# Altium schematic sheet MCU_STM32F407_V2005.SchDoc
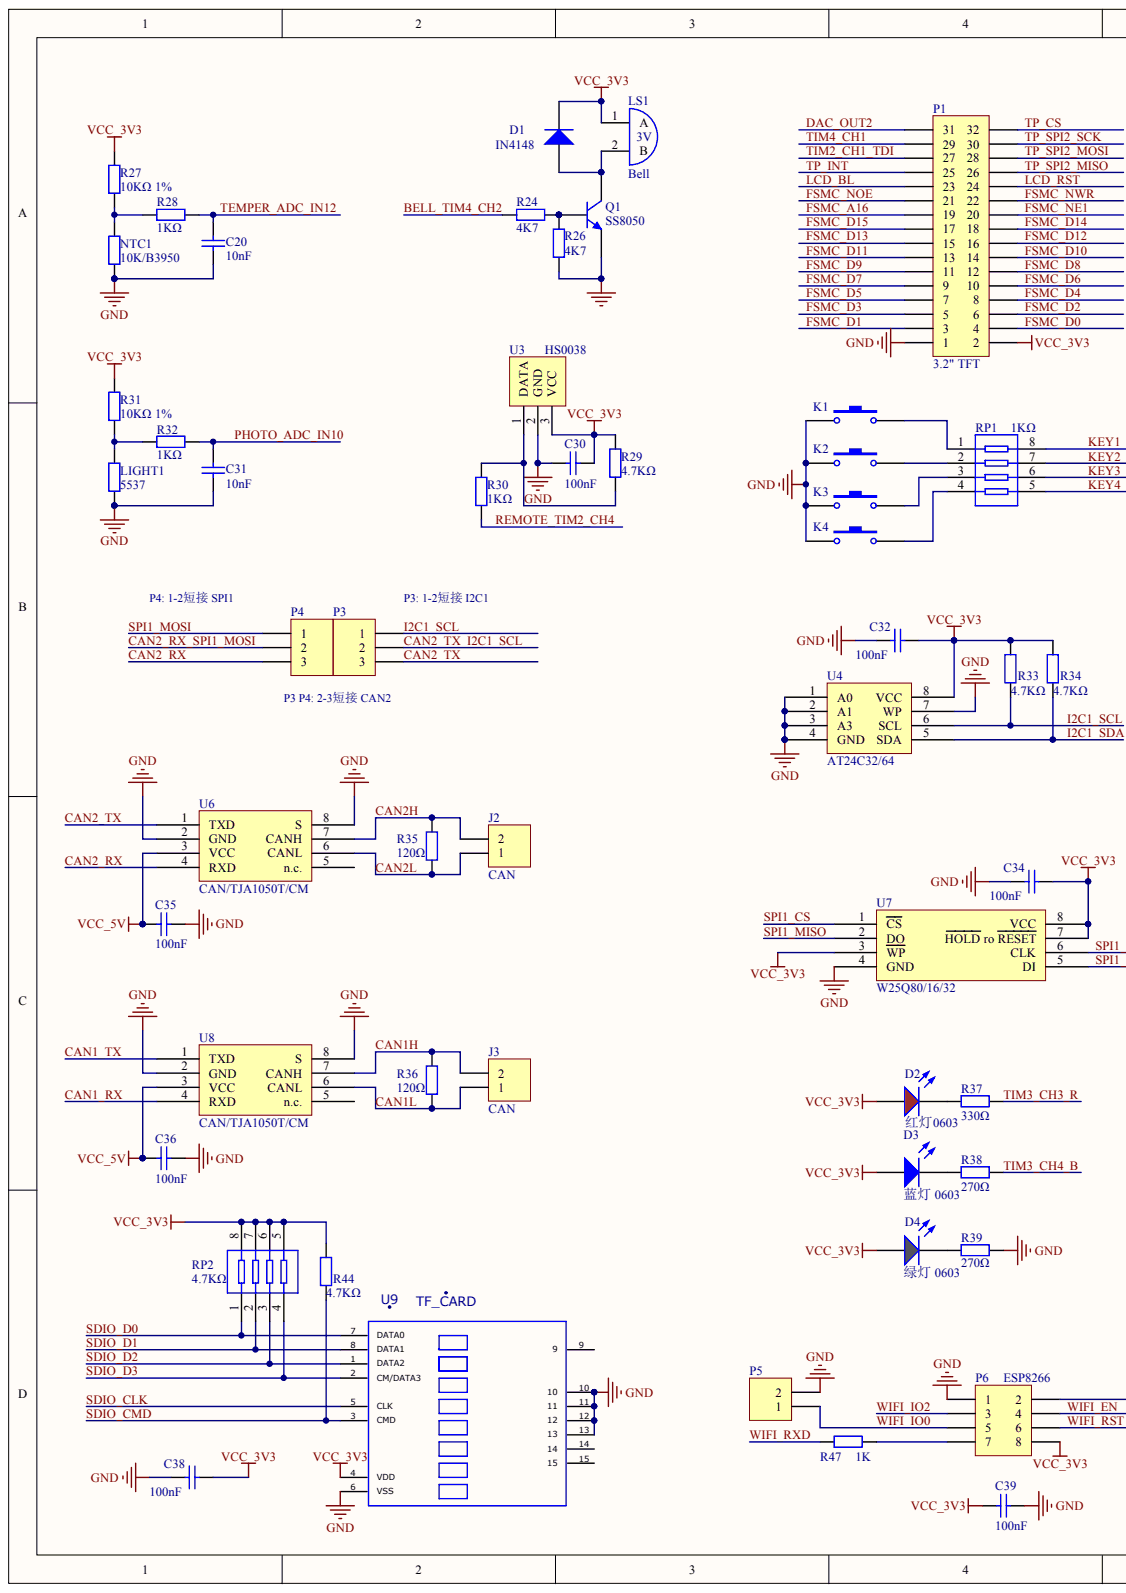
[diagram p0: MCU_STM32F407_V2005.SchDoc, left]
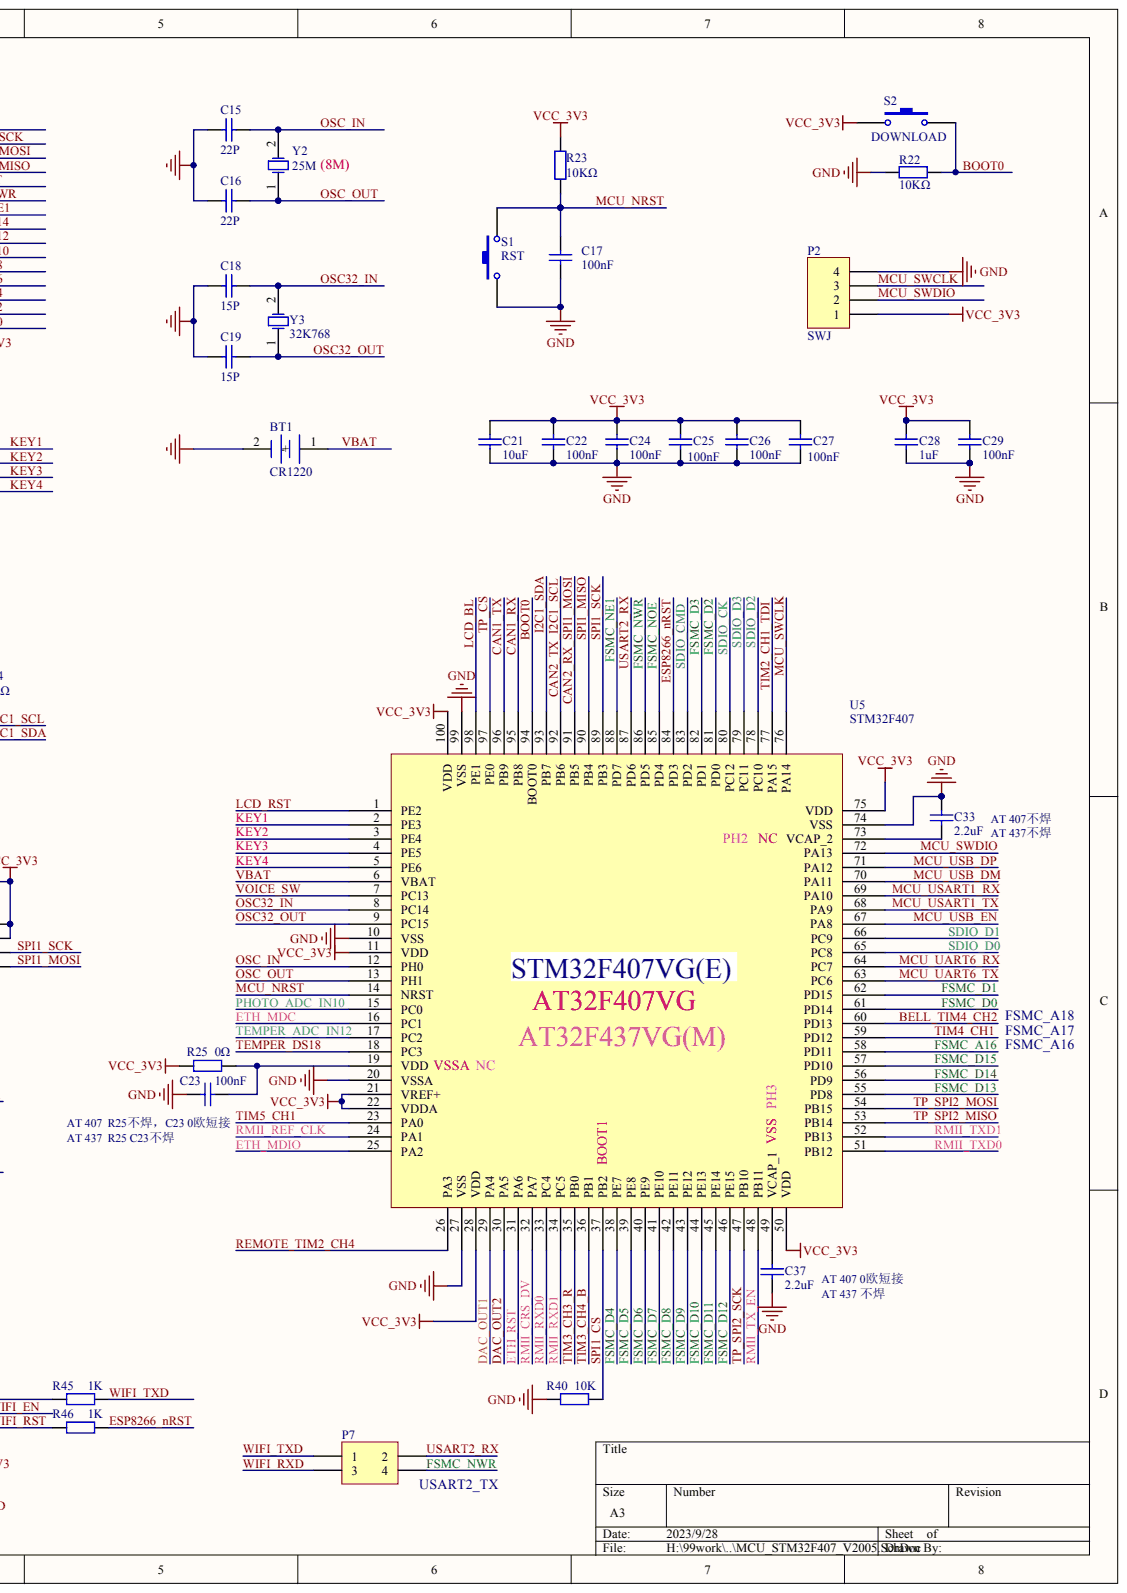
[diagram p1: MCU_STM32F407_V2005.SchDoc, right]

## Parts
BT1  CR1220
C15  22P
C16  22P
C17  100nF
C18  15P
C19  15P
C20  10nF
C21  10uF
C22  100nF
C23  100nF
C24  100nF
C25  100nF
C26  100nF
C27  100nF
C28  1uF
C29  100nF
C30  100nF
C31  10nF
C32  100nF
C33  2.2uF
C34  100nF
C35  100nF
C36  100nF
C37  2.2uF
C38  100nF
C39  100nF
D1  IN4148
D2  红灯0603  Package: 0603
D3  蓝灯 0603  Package: 0603
D4  绿灯 0603  Package: 0603
J2  CAN
J3  CAN
K1  KEY1  (value not drawn)
K2  KEY2  (value not drawn)
K3  KEY3  (value not drawn)
K4  KEY4  (value not drawn)
LIGHT1  5537
LS1  Bell  value 3V
NTC1  10K/B3950
P1  3.2" TFT
P2  SWJ
P3  (no value)
P4  (no value)
P5  (no value)
P6  ESP8266
P7  (no value)
Q1  SS8050
R22  10KΩ
R23  10KΩ
R24  value 4K7  (hidden comment: 100Ω)
R25  0Ω
R26  value 4K7  (hidden comment: 100Ω)
R27  10KΩ 1%
R28  1KΩ
R29  4.7KΩ
R30  1KΩ
R31  10KΩ 1%
R32  1KΩ
R33  4.7KΩ
R34  4.7KΩ
R35  120Ω
R36  120Ω
R37  330Ω
R38  270Ω
R39  270Ω
R40  10K
R44  4.7KΩ
R45  1K
R46  1K
R47  1K
RP1  1KΩ
RP2  4.7KΩ
S1  RST
S2  DOWNLOAD
U3  HS0038
U4  AT24C32/64
U5  STM32F407
U6  CAN/TJA1050T/CM
U7  W25Q80/16/32
U8  CAN/TJA1050T/CM
U9  TF_CARD
Y2  25M
Y3  32K768

## Nets
GND: BT1.2 C15.1 C16.1 C17.1 C18.1 C19.1 C20.2 C21.1 C22.1 C23.2 C24.1 C25.1 C26.1 C27.1 C28.1 C29.1 C30.2 C31.2 C32.1 C33.1 C34.1 C35.1 C36.1 C37.1 C38.1 C39.1 K1.1 K2.1 K3.1 K4.1 LIGHT1.1 NTC1.1 P1.1 P2.4 P5.2 P6.1 Q1.2 R22.2 R26.2 R39.2 R40.1 S1.1 U3.2 U4.1(A0) U4.2(A1) U4.3(A3) U4.4(GND) U4.7(WP) U5.10(VSS) U5.20(VSSA) U5.27(VSS) U5.74(VSS) U5.99(VSS) U6.2(GND) U6.8(S) U7.4(GND) U8.2(GND) U8.8(S) U9.6(VSS) U9.10 U9.11 U9.12 U9.13
VCC_3V3: C21.2 C22.2 C24.2 C25.2 C26.2 C27.2 C28.2 C29.2 C30.1 C32.2 C34.2 C38.2 C39.2 D1.2 D2.A D3.A D4.A LS1.1 P1.2 P2.1 P6.8 R23.2 R25.1 R27.2 R29.2 R31.2 R33.2 R34.2 R44.2 RP2.5 RP2.6 RP2.7 RP2.8 S2.1 U3.3 U4.8(VCC) U5.11(VDD) U5.21(VREF+) U5.22(VDDA) U5.28(VDD) U5.50(VDD) U5.75(VDD) U5.100(VDD) U7.3(WP) U7.7(HOLD_ro_RESET) U7.8(VCC) U9.4(VDD)
VCC_5V: C35.2 C36.2 U6.3(VCC) U8.3(VCC)
BELL_TIM4_CH2: R24.2 U5.60(PD13)
BOOT0: R22.1 S2.2 U5.94(BOOT0)
CAN1_RX: U5.95(PB8) U8.4(RXD)
CAN1_TX: U5.96(PB9) U8.1(TXD)
CAN1H: J3.2 R36.2 U8.7(CANH)
CAN1L: J3.1 R36.1 U8.6(CANL)
CAN2_RX: P4.3 U6.4(RXD)
CAN2_RX_SPI1_MOSI: P4.2 U5.91(PB5)
CAN2_TX: P3.3 U6.1(TXD)
CAN2_TX_I2C1_SCL: P3.2 U5.92(PB6)
CAN2H: J2.2 R35.2 U6.7(CANH)
CAN2L: J2.1 R35.1 U6.6(CANL)
DAC_OUT1: U5.29(PA4)
DAC_OUT2: P1.31 U5.30(PA5)
ESP8266_NRST: R46.2 U5.84(PD3)
ETH_MDC: U5.16(PC1)
ETH_MDIO: U5.25(PA2)
ETH_RST: U5.31(PA6)
FSMC_A16: P1.19 U5.58(PD11)
FSMC_D0: P1.4 U5.61(PD14)
FSMC_D1: P1.3 U5.62(PD15)
FSMC_D2: P1.6 U5.81(PD0)
FSMC_D3: P1.5 U5.82(PD1)
FSMC_D4: P1.8 U5.38(PE7)
FSMC_D5: P1.7 U5.39(PE8)
FSMC_D6: P1.10 U5.40(PE9)
FSMC_D7: P1.9 U5.41(PE10)
FSMC_D8: P1.12 U5.42(PE11)
FSMC_D9: P1.11 U5.43(PE12)
FSMC_D10: P1.14 U5.44(PE13)
FSMC_D11: P1.13 U5.45(PE14)
FSMC_D12: P1.16 U5.46(PE15)
FSMC_D13: P1.15 U5.55(PD8)
FSMC_D14: P1.18 U5.56(PD9)
FSMC_D15: P1.17 U5.57(PD10)
FSMC_NE1: P1.20 U5.88(PD7)
FSMC_NOE: P1.21 U5.85(PD4)
FSMC_NWR: P1.22 P7.4 U5.86(PD5)
I2C1_SCL: P3.1 R33.1 U4.6(SCL)
I2C1_SDA: R34.1 U4.5(SDA) U5.93(PB7)
KEY1: RP1.8 U5.2(PE3)
KEY2: RP1.7 U5.3(PE4)
KEY3: RP1.6 U5.4(PE5)
KEY4: RP1.5 U5.5(PE6)
LCD_BL: P1.23 U5.98(PE1)
LCD_RST: P1.24 U5.1(PE2)
MCU_NRST: C17.2 R23.1 S1.2 U5.14(NRST)
MCU_SWCLK: P2.3 U5.76(PA14)
MCU_SWDIO: P2.2 U5.72(PA13)
MCU_UART6_RX: U5.64(PC7)
MCU_UART6_TX: U5.63(PC6)
MCU_USART1_RX: U5.69(PA10)
MCU_USART1_TX: U5.68(PA9)
MCU_USB_DM: U5.70(PA11)
MCU_USB_DP: U5.71(PA12)
MCU_USB_EN: U5.67(PA8)
OSC32_IN: C18.2 U5.8(PC14) Y3.2
OSC32_OUT: C19.2 U5.9(PC15) Y3.1
OSC_IN: C15.2 U5.12(PH0) Y2.2
OSC_OUT: C16.2 U5.13(PH1) Y2.1
PHOTO_ADC_IN10: C31.1 R32.1 U5.15(PC0)
REMOTE_TIM2_CH4: R30.2 U5.26(PA3)
RMII_CRS_DV: U5.32(PA7)
RMII_REF_CLK: U5.24(PA1)
RMII_RXD0: U5.33(PC4)
RMII_RXD1: U5.34(PC5)
RMII_TX_EN: U5.48(PB11)
RMII_TXD0: U5.51(PB12)
RMII_TXD1: U5.52(PB13)
SDIO_CK: U5.80(PC12)
SDIO_CLK: U9.5(CLK)
SDIO_CMD: R44.1 U5.83(PD2) U9.3(CMD)
SDIO_D0: RP2.1 U5.65(PC8) U9.7(DATA0)
SDIO_D1: RP2.2 U5.66(PC9) U9.8(DATA1)
SDIO_D2: RP2.3 U5.78(PC10) U9.1(DATA2)
SDIO_D3: RP2.4 U5.79(PC11) U9.2(CM/DATA3)
SPI1_CS: R40.2 U5.37(PB2) U7.1(CS)
SPI1_MISO: U5.90(PB4) U7.2(DO)
SPI1_MOSI: P4.1 U7.5(DI)
SPI1_SCK: U5.89(PB3) U7.6(CLK)
TEMPER_ADC_IN12: C20.1 R28.1 U5.17(PC2)
TEMPER_DS18: U5.18(PC3)
TIM2_CH1_TDI: P1.27 U5.77(PA15)
TIM3_CH3_R: R37.2 U5.35(PB0)
TIM3_CH4_B: R38.2 U5.36(PB1)
TIM4_CH1: P1.29 U5.59(PD12)
TIM5_CH1: U5.23(PA0)
TP_CS: P1.32 U5.97(PE0)
TP_INT: P1.25
TP_SPI2_MISO: P1.26 U5.53(PB14)
TP_SPI2_MOSI: P1.28 U5.54(PB15)
TP_SPI2_SCK: P1.30 U5.47(PB10)
USART2_RX: P7.2 U5.87(PD6)
VBAT: BT1.1 U5.6(VBAT)
VOICE_SW: U5.7(PC13)
WIFI_EN: P6.4
WIFI_IO0: P5.1 P6.5
WIFI_IO2: P6.3
WIFI_RST: P6.6 R46.1
WIFI_RXD: P7.3 R47.1
WIFI_TXD: P7.1 R45.2
NetC23_1: C23.1 R25.2 U5.19(VDD_VSSA)
NetC33_2: C33.2 U5.73(VCAP_2)
NetC37_2: C37.2 U5.49(VCAP_1)
NetD1_1: D1.1 LS1.2 Q1.3
NetD2_K: D2.K R37.1
NetD3_K: D3.K R38.1
NetD4_K: D4.K R39.1
NetK1_2: K1.2 RP1.1
NetK2_2: K2.2 RP1.2
NetK3_2: K3.2 RP1.3
NetK4_2: K4.2 RP1.4
NetLIGHT1_2: LIGHT1.2 R31.1 R32.2
NetNTC1_2: NTC1.2 R27.1 R28.2
NetP6_2: P6.2 R45.1
NetP6_7: P6.7 R47.2
NetQ1_1: Q1.1 R24.1 R26.1
NetR29_1: R29.1 R30.1 U3.1

Not connected: U6 5(n.c.); U8 5(n.c.); U9 9 14 15

## Library data of the parts
R24 R26 = (no symbol name): Manufacturer: Generic Components; Class: Passive; SubClass: Resistor; Published: 08/06/2000; Publisher: Altium Hobart Technology Centre
BT1 C15-C39 D1-D4 J2 J3 K1-K4 LIGHT1 LS1 NTC1 P1-P7 Q1 R22 R23 R25 R27-R40 R44-R47 RP1 RP2 S1 S2 U3-U9 Y2 Y3 = (no symbol name)
  BT1: Published: 24-Mar-1999; LatestRevisionDate: 17-Jul-2002; LatestRevisionNote: Re-released for DXP Platform.; PackageReference: BAT-2; PackageDescription: Battery; 2 Leads
  C15 C16 C18 C19: Published: 8-Jun-2000; LatestRevisionDate: 17-Jul-2002; LatestRevisionNote: Re-released for DXP Platform.; PackageReference: RAD-0.3; PackageDescription: Radial Cap, Thru-Hole; 2 Leads; 0.3 in Pin Spacing
  C17 C21-C30 C33 C35-C37 C39: ComponentLink1Description: 供应商链接; ComponentLink1URL: http://www.szlcsc.com/product/details_15331.html; Package: 0603; Supplier: LC; SuppliersPartNumber: C14663
  C20 C31 C32 C34 C38: ComponentLink1Description: 供应商链接; ComponentLink1URL: http://www.szlcsc.com/product/details_24709.html; Package: 0603; Supplier: LC; SuppliersPartNumber: C23969
  D1: ComponentLink1Description: 供应商链接; ComponentLink1URL: http://www.szlcsc.com/product/details_2485.html; Package: SOD-323; Supplier: LC; SuppliersPartNumber: C2128
  D2: ComponentLink1Description: 供应商链接; ComponentLink1URL: http://www.szlcsc.com/product/details_2659.html; Supplier: LC; SuppliersPartNumber: C2286
  D3: ComponentLink1Description: 供应商链接; ComponentLink1URL: http://www.szlcsc.com/product/details_73150.html; Supplier: LC; SuppliersPartNumber: C72041
  D4: ComponentLink1Description: 供应商链接; ComponentLink1URL: http://www.szlcsc.com/product/details_73152.html; Supplier: LC; SuppliersPartNumber: C72043
  J2 J3 P1-P7: LatestRevisionDate: 17-Jul-2002; LatestRevisionNote: Re-released for DXP Platform.
  K1-K4 S1 S2: Published: 24-Mar-1999; LatestRevisionDate: 17-Jul-2002; LatestRevisionNote: Re-released for DXP Platform.; PackageReference: SPST-2; PackageDescription: Switch; 2 Leads
  LIGHT1 NTC1 R22 R23 R25 R27-R40 R44-R47: ComponentLink1Description: 供应商链接; ComponentLink1URL: http://www.szlcsc.com/product/details_26547.html; Package: 0603; Supplier: LC; SuppliersPartNumber: C25804
  LS1: Published: 24-Mar-1999; LatestRevisionDate: 17-Jul-2002; LatestRevisionNote: Re-released for DXP Platform.
  Q1: ComponentLink1Description: 供应商链接; ComponentLink1URL: http://www.szlcsc.com/product/details_2507.html; Package: SOT-23; Supplier: LC; SuppliersPartNumber: C2150
  RP1 RP2: ComponentLink1Description: 供应商链接; ComponentLink1URL: http://www.szlcsc.com/product/details_20907.html; Package: 0603*4; Supplier: LC; SuppliersPartNumber: C20197
  U4: ComponentLink1Description: 供应商链接; ComponentLink1URL: http://www.szlcsc.com/product/details_6947.html; Package: SOIC-8_150mil; Supplier: LC; SuppliersPartNumber: C6482
  U6 U8: ComponentLink1Description: 供应商链接; ComponentLink1URL: http://www.szlcsc.com/product/details_7424.html; Package: SOIC-8_150mil; Supplier: LC; SuppliersPartNumber: C6952
  U7: ComponentLink1Description: 供应商链接; ComponentLink1URL: http://www.szlcsc.com/product/details_111868.html; Package: SOIC-8; Supplier: LC; SuppliersPartNumber: C110643
  U9: PCB DECAL: TF_CARD
  Y2 Y3: Published: 8-Jun-2000; LatestRevisionDate: 17-Jul-2002; LatestRevisionNote: Re-released for DXP Platform.; PackageReference: R38; PackageDescription: Crystal, Thru-Hole; 2 Leads; Body 3.1 x 8.2 mm (Dia.xH)
  BT1 C15 C16 C18 C19 J2 J3 K1-K4 LS1 P1-P7 S1 S2 Y2 Y3: Publisher: Altium Limited
